# Altium schematic sheet sdd_peripherals.SchDoc(sdd_peripherals)
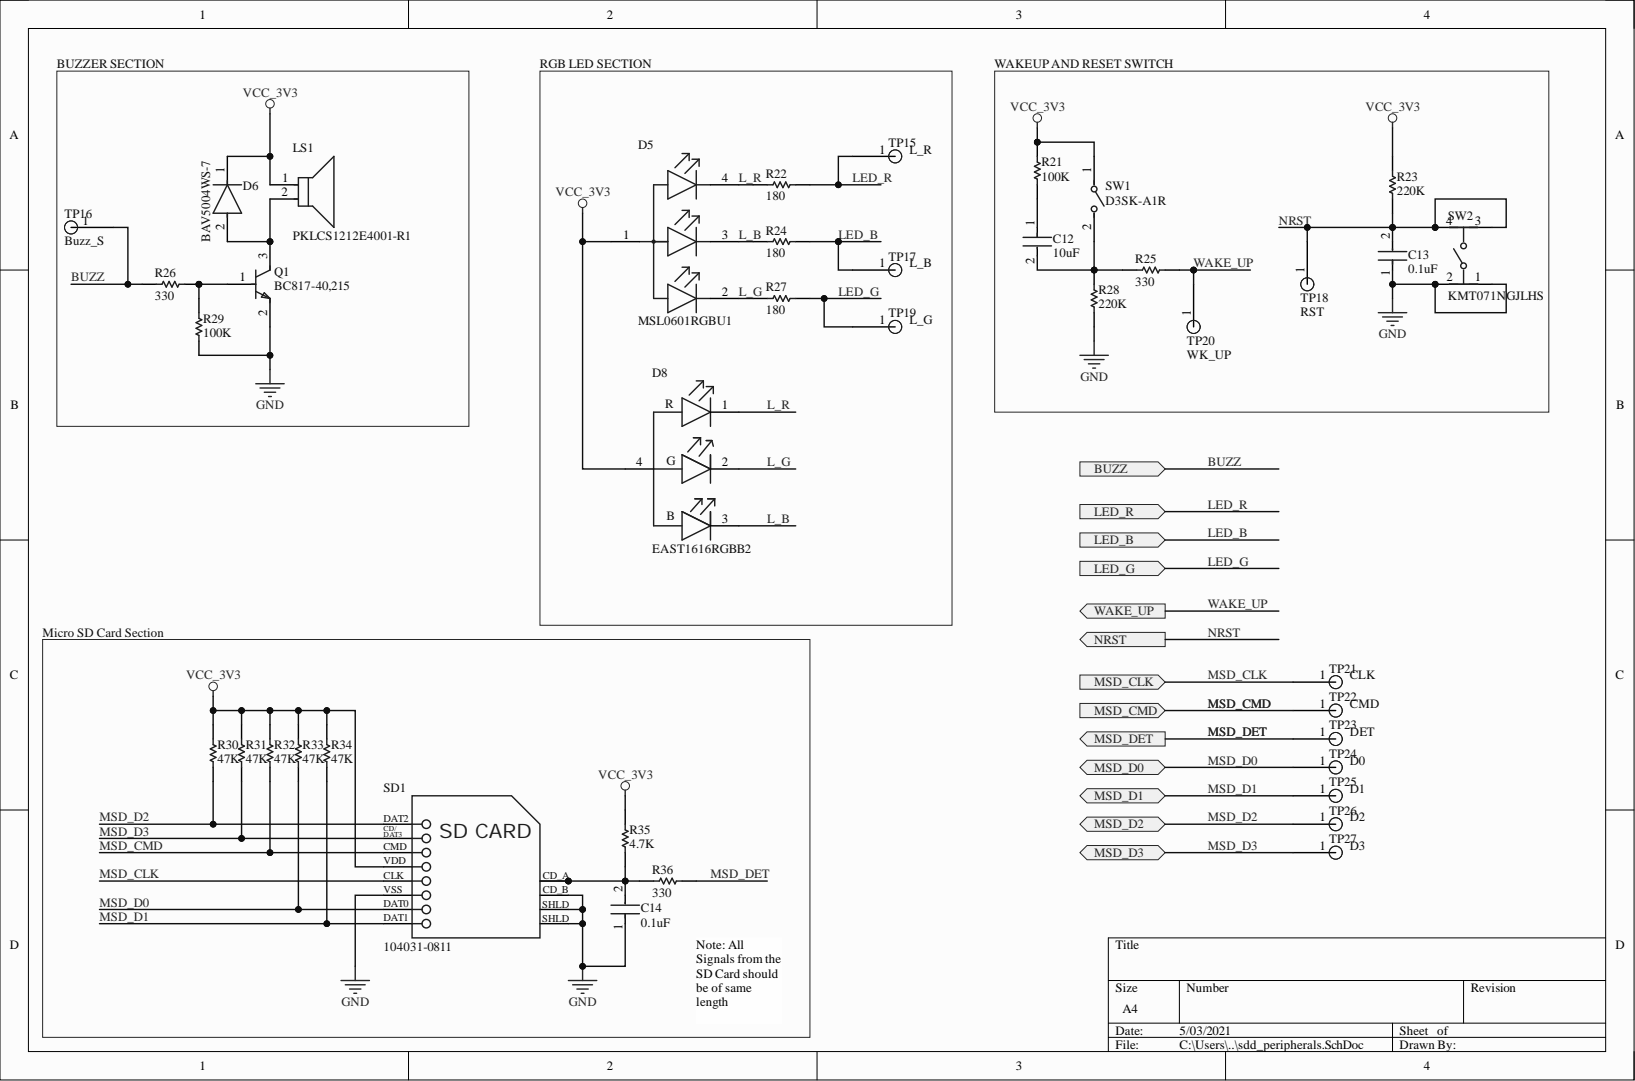
[diagram p0: sdd_peripherals.SchDoc]

## Parts
C12  10uF  [FP-GRJ155-0_2-MFG]
C13  0.1uF  [FP-GRM022-0_02-IPC_A]
C14  0.1uF  [FP-GRM022-0_02-IPC_A]
D5  MSL0601RGBU1  [FP-MSL-0601-MFG]
D6  BAV5004WS-7  [FP-SOD323-MFG]
D8  EAST1616RGBB2  [FP-EAST1616RGBB2-MFG]
LS1  PKLCS1212E4001-R1  [FP-PKLCS1212E4001-R1-MFG]
Q1  BC817-40,215  [FP-SOT23-MFG]
R21  100K  [RESC1005X40X25ML05T05]
R22  180  [RESC1005X40X25LL05T05]
R23  220K  [RESC1005X40X25ML05T10]
R24  180  [RESC1005X40X25LL05T05]
R25  330  [RESC1005X40X25LL05T10]
R26  330  [RESC1005X40X25LL05T10]
R27  180  [RESC1005X40X25LL05T05]
R28  220K  [RESC1005X40X25ML05T10]
R29  100K  [RESC1005X40X25ML05T05]
R30  47K  [RESC1005X40X25LL05T05]
R31  47K  [RESC1005X40X25LL05T05]
R32  47K  [RESC1005X40X25LL05T05]
R33  47K  [RESC1005X40X25LL05T05]
R34  47K  [RESC1005X40X25LL05T05]
R35  4.7K  [RESC1005X40X25LL05T10]
R36  330  [RESC1005X40X25LL05T10]
SD1  104031-0811  [MOLX-104031-0811_V]
SW1  D3SK-A1R  [FP-D3SK-A1R-MFG]
SW2  KMT071NGJLHS  [FP-KMT071NGJLHS-MFG]
TP15  L_R  [Testpoint2]  (no BOM)
TP16  Buzz_S  [Testpoint2]  (no BOM)
TP17  L_B  [Testpoint2]  (no BOM)
TP18  RST  [Testpoint2]  (no BOM)
TP19  L_G  [Testpoint2]  (no BOM)
TP20  WK_UP  [Testpoint2]  (no BOM)
TP21  CLK  [Testpoint2]  (no BOM)
TP22  CMD  [Testpoint2]  (no BOM)
TP23  DET  [Testpoint2]  (no BOM)
TP24  D0  [Testpoint2]  (no BOM)
TP25  D1  [Testpoint2]  (no BOM)
TP26  D2  [Testpoint2]  (no BOM)
TP27  D3  [Testpoint2]  (no BOM)

## Ports
BUZZ, LED_B, LED_G, LED_R, MSD_CLK, MSD_CMD, MSD_D0, MSD_D1, MSD_D2, MSD_D3, MSD_DET, NRST, WAKE_UP

## Nets
GND: C13.1 C14.1 Q1.2 R28.1 R29.1 SD1.6 SD1.10 SD1.11 SD1.12 SW2.1 SW2.2
VCC_3V3: D5.1 D6.1 D8.4 LS1.1 R21.2 R23.2 R30.2 R31.2 R32.2 R33.2 R34.2 R35.2 SD1.4 SW1.1
BUZZ: R26.1 TP16.1 ; port BUZZ
L_B: D5.3 D8.3 R24.1
L_G: D5.2 D8.2 R27.1
L_R: D5.4 D8.1 R22.1
LED_B: R24.2 TP17.1 ; port LED_B
LED_G: R27.2 TP19.1 ; port LED_G
LED_R: R22.2 TP15.1 ; port LED_R
MSD_CLK: SD1.5 TP21.1 ; port MSD_CLK
MSD_CMD: R32.1 SD1.3 TP22.1 ; port MSD_CMD
MSD_D0: R33.1 SD1.7 TP24.1 ; port MSD_D0
MSD_D1: R34.1 SD1.8 TP25.1 ; port MSD_D1
MSD_D2: R30.1 SD1.1 TP26.1 ; port MSD_D2
MSD_D3: R31.1 SD1.2(SD_CARD) TP27.1 ; port MSD_D3
MSD_DET: R36.2 TP23.1 ; port MSD_DET
NRST: C13.2 R23.1 SW2.3 SW2.4 TP18.1 ; port NRST
WAKE_UP: R25.2 TP20.1 ; port WAKE_UP
NetC12_1: C12.1 R21.1
NetC12_2: C12.2 R25.1 R28.2 SW1.2
NetC14_2: C14.2 R35.1 R36.1 SD1.9
NetD6_2: D6.2 LS1.2 Q1.3
NetQ1_1: Q1.1 R26.2 R29.2

## Library data of the parts
C12 = CMP-06035-062410-1: CAP CER 10UF 6.3V X5R 0402; Tolerance: 20%; Capacitance: 10uF; Case Code (Imperial): 0402; Case Code (Metric): 1005; Case/Package: 0402; Component Kind: Standard; Features: Soft Termination; Length: 0.039inch; Library Name: Altium Content Vault; Max Operating Temperature: 85°C; Min Operating Temperature: -55°C; Mount: Surface Mount; Packaging: Cut Tape; RoHS Compliant: Yes; Thickness: 0.028inch; Voltage Rating: 6.3V; Width: 0.02inch
C13 C14 = CMP-06035-048848-1: Chip Multilayer Ceramic Capacitors for General Purpose, 01005, 0.10uF, X5R, 15%, 20%, 6.3V; Capacitance: 100nF; Case Code (Imperial): 1005; Case Code (Metric): 1005; Case/Package: 0402; Component Kind: Standard; Dielectric: X5R; Height: 0.2mm; Lead Free: Lead Free; Lead Pitch: 0.232inch; Length: 0.016inch; Library Name: Altium Content Vault; Max Operating Temperature: 85°C; Min Operating Temperature: -55°C; Mount: Surface Mount; Package Quantity: 40000; Packaging: Tape and Reel; Termination: SMD/SMT; Thickness: 0.009inch; Tolerance: 20%; Voltage Rating: 6.3V; Voltage Rating (DC): 6.3V; Weight: 0.000212oz; Width: 0.2mm
D5 = CMP-08952-000021-1: SMALL AND HIGH BRIGHTNESS SIDE-V; Manufacturer: Rohm; Colour: Red, Green, Blue; Forward Current: 20mA; Forward Voltage: 2.1/3.3/3.2V; Led Orientation: Side View; Luminous Intensity: 700/1250/360mcd; Power Dissipation: 300mW; Reverse Voltage: 5V; Wavelength: 624/527/470nm; Automotive: No; Category: Diode; Component Kind: Standard; ComponentLink2Description: Datasheet; ComponentLink2URL: https://fscdn.rohm.com/en/products/databook/datasheet/opto/led/chip_multi/msl0601rgb-e.pdf; Device Class L1: Optoelectronics; Device Class L2: LEDs; Device Class L3: unset; Digikey Description: SMALL AND HIGH BRIGHTNESS SIDE-V; Height: 1.1mm; Lead Free: Yes; Library Name: Altium Content Vault; Manufacturer Part Number: MSL0601RGBU1; Mouser Description: Led, Red/grn/blu, 700MCD/1.25CD/360MCD; Octopart: https://octopart.com/msl0601rgbu1-rohm-92811264; Package: MSL-0601; RoHS: TRUE; Temperature: -40°C to +85°C; Temperature Range High: +85°C; Temperature Range Low: -40°C
D6 = CMP-12791-000015-1: DIODE GP 350V 300MA SOD323; Manufacturer: Diodes Inc.; Automotive Grade: Grade 0; Capacitance: 0.9pF; Max Junction Temp: +150°C; Max Repetitive Reverse Voltage: 400V; Max Surge Forward Current: 3A; Peak Reverse Current: 1uA; Standoff Height: 0.05mm; Value: 0.9pF; Automotive: Yes; Category: Diode; Component Kind: Standard; ComponentLink2Description: Datasheet; ComponentLink2URL: https://www.diodes.com/assets/Datasheets/BAV5004WS.pdf; Device Class L1: Discrete Semiconductors; Device Class L2: Diodes; Device Class L3: Rectifier Diodes; Digikey Description: DIODE GP 350V 300MA SOD323; Footprint Url: https://www.diodes.com/assets/Package-Files/SOD323.pdf; Forward Current: 300mA; Height: 1.2mm; Lead Free: Yes; Library Name: Altium Content Vault; Manufacturer Part Number: BAV5004WS-7; Mouser Description: Diodes - General Purpose, Power, Switching HiVolt Switching 200mW 50ns 0.9pF; Octopart: https://octopart.com/bav5004ws-7-diodes+inc.-46917595; Package: SOD323; Reverse Voltage: 350V; RoHS: TRUE; Temperature: -55°C to +150°C; Temperature Range High: +150°C; Temperature Range Low: -55°C
D8 = CMP-51564-000002-1: LED RGB DIFFUSED 4SMD; Manufacturer: Everlight; Colour: Blue, Green, Red; Forward Current: 5mA; Forward Voltage: 3/3/2.1V; Led Orientation: Top View; Luminous Intensity: 36/140/45mcd; Peak Emmision Wavelength: 468/518/632nm; Power Dissipation: 95/95/60mW; Reverse Voltage: 5V; Viewing Angle: 120°; Wavelength: 475/535/628nm; Automotive: No; Category: Diode; Component Kind: Standard; ComponentLink2Description: Datasheet; ComponentLink2URL: https://everlightamericas.com/index.php?controller=attachment&id_attachment=2426; Device Class L1: Optoelectronics; Device Class L2: LEDs; Device Class L3: unset; Digikey Description: LED RGB DIFFUSED 4SMD; Height: 0.45mm; Lead Free: Yes; Library Name: Altium Content Vault; Manufacturer Part Number: EAST1616RGBB2; Octopart: https://octopart.com/east1616rgbb2-everlight-80328051; Package: 0; RoHS: TRUE; Temperature: -40°C to +85°C; Temperature Range High: +85°C; Temperature Range Low: -40°C
LS1 = CMP-2000-05277-2: AUDIO PIEZO TRANSDUCER 25V SMD; Frequency: 4kHz; Nominal Input Voltage: 3V; Washable: No; Component Kind: Standard; Depth: 12mm; Diameter: 12mm; Height: 3mm; Lead Free: Lead Free; Length: 12mm; Library Name: Altium Content Vault; Max Frequency: 4kHz; Max Operating Temperature: 70°C; Max Supply Voltage: 25V; Min Operating Temperature: -20°C; Mount: Surface Mount; Package Quantity: 1; Packaging: Tape and Reel; Radiation Hardening: No; REACH SVHC: No SVHC; Self Resonant Frequency: 4kHz; Termination: Solder; Voltage Rating: 25V; Voltage Rating (DC): 25V; Width: 12mm
Q1 = CMP-14406-000007-1: TRANS NPN 45V 0.5A SOT23; Manufacturer: Nexperia; Automotive Grade: Grade 0; Collector Base Voltage: 50V; Collector Emitter Saturation Voltage: 700mV; Emitter Base Voltage: 5V; Hfe Max: 600; Hfe Min: 250; Hfe Testic: 100mA; Hfe Testvce: 1V; Max Collector Current: 500mA; Max Junction Temp: +150°C; Number Of Elements: 1; Power Dissipation: 250mW; Rthja Max: 500K/W; Unity Gain Freq: 100MHz; Ambient Temperature Range High: +150°C; Ambient Temperature Range Low: -65°C; Automotive: Yes; Category: Trans; Collector Emitter Breakdown Voltage: 45V; Collector Emitter Voltage: 45V; Component Kind: Standard; ComponentLink2Description: Datasheet; ComponentLink2URL: https://assets.nexperia.com/documents/data-sheet/BC817_SER.pdf; Device Class L1: Discrete Semiconductors; Device Class L2: Transistors; Device Class L3: BJTs; Digikey Description: TRANS NPN 45V 0.5A SOT23; Footprint Url: https://assets.nexperia.com/documents/outline-drawing/SOT23.pdf; Height: 1.1mm; Ipc Land Pattern Name: SOT95P230X100-3; Lead Free: Yes; Library Name: Altium Content Vault; Manufacturer Part Number: BC817-40,215; Mouser Description: BC817 Series 45 V 500 mA 250 mW SMT NPN General-Purpose Transistor - SOT-23-; Number Of Npn: 1; Octopart: https://octopart.com/bc817-40%2C215-nexperia-78739081; Package: SOT23; RoHS: TRUE; Temperature: to +150°C; Temperature Range High: +150°C
R21 R29 = CMP-1011-00736-2: Manufacturer: Vishay Dale; Part Number: CRCW0402100KFKTD; Component Kind: Standard; Library Name: Altium Content Vault
R22 R24 R27 = CMP-2002-00468-1: Manufacturer: Panasonic; Part Number: ERJ-2GEJ181X; Component Kind: Standard; Library Name: Altium Content Vault
R23 R28 = CMP-2002-07958-1: Manufacturer: Yageo / Phycomp; Part Number: RC0402JR-07220KL; Component Kind: Standard; Library Name: Altium Content Vault
R25 R26 R36 = CMP-2002-07613-1: Manufacturer: Yageo / Phycomp; Part Number: RC0402FR-07330RL; Component Kind: Standard; Library Name: Altium Content Vault
R30-R34 = CMP-2002-00545-1: Manufacturer: Panasonic; Part Number: ERJ-2GEJ473X; Component Kind: Standard; Library Name: Altium Content Vault
R35 = CMP-2002-08011-1: Manufacturer: Yageo / Phycomp; Part Number: RC0402JR-074K7L; Component Kind: Standard; Library Name: Altium Content Vault
SD1 = CMP-2000-05825-1: Micro SD Card, RA, -25 to 85 degC, 8-Pin SMD, RoHS, Tape and Reel; Brand: Molex; Current Rating: 500mA; Insertion - Extraction Style: Push-Pull; Mating Cycles: 10000; Number of Contacts: 8; Pitch: 1.1mm; Voltage Rating: 10VAC/DC; Card Type: microSD; Component Kind: Standard; Contact Material: PhosphorBronze; Contact Plating: Gold; Datasheet URL: http://www.molex.com/pdm_docs/sd/1040310811_sd.pdf; Datasheet Version: Rev. E, 04/2006; Height: 1.42mm; Housing Material: LiquidCrystalPolymer(LCP); Length: 11.4mm; Library Name: Altium Content Vault; Manufacturer: Molex; Manufacturer URL: http://www.molex.com/; Mounting Technology: SurfaceMount; Mounting Type: Standard; Number of Rows: 1Row; Orientation: RightAngle; Package Description: 8-Pin Surface Mount Device, Body 11.95 x 11.4 mm, Pitch 1.1 mm; Package Reference: 104031-0811; Packaging: Reel; Product: CardConnectors; Product Category: MemoryCardConnectors; RoHS: True; Series: 104031; Termination Style: SMD
SW1 = CMP-08833-000007-1: SWITCH DETECTOR SPST-NO 1MA 5V; Actuator Length: 3.5mm; Connector Type: J-Bend; Contact Resistance: 3R; Electrical Life: 100000Cycles; Mechanical Life: 150000Cycles; Operating Force: 41gf; Operating Position: 0.185inch; Switch Function: Off-Mom; Throw Configuration: SPST; Case/Package: SMD/SMT; Component Kind: Standard; Current Rating: 1mA; Library Name: Altium Content Vault; Max Operating Temperature: 85°C; Max Voltage Rating (DC): 5V; Min Operating Temperature: -25°C; Mount: Surface Mount; Number of Terminals: 2; Orientation: Right Angle; Package Quantity: 1; Packaging: Tape and Reel; Termination: Gull Wing; Voltage Rating (DC): 5V; Weight: 0.000705oz
SW2 = CMP-10040-000017-1: SWITCH TACTILE SPST-NO 0.05A 32V; Actuator Type: Standard; Current Rating: 50mA; Illumination Type: Non-Illuminated; Mechanical Life: 300000Cycles; Operating Force: 2.3N; Power Rating: 500mW; Ratings: IP68; Switch Function: Off-Mom; Switch Travel: 0.15mm; Throw Configuration: SPST; Case/Package: SMD/SMT; Component Kind: Standard; Contact Material: Silver; Contact Plating: Silver; Depth: 3.4mm; Lead Free: Lead Free; Length: 2.6mm; Library Name: Altium Content Vault; Max Current Rating: 50mA; Max Operating Temperature: 85°C; Max Voltage Rating (DC): 32V; Min Operating Temperature: -40°C; Mount: Surface Mount; Orientation: Straight; Package Quantity: 5000; Packaging: Tape and Reel; Radiation Hardening: No; REACH SVHC: No SVHC; Termination: J-Lead; Voltage Rating (DC): 32V
TP15-TP27 = Testpoint2: Library Name: TestPoint_Round.IntLib
